# Altium schematic sheet J19B_EM3B_PP0_MCU.SchDoc
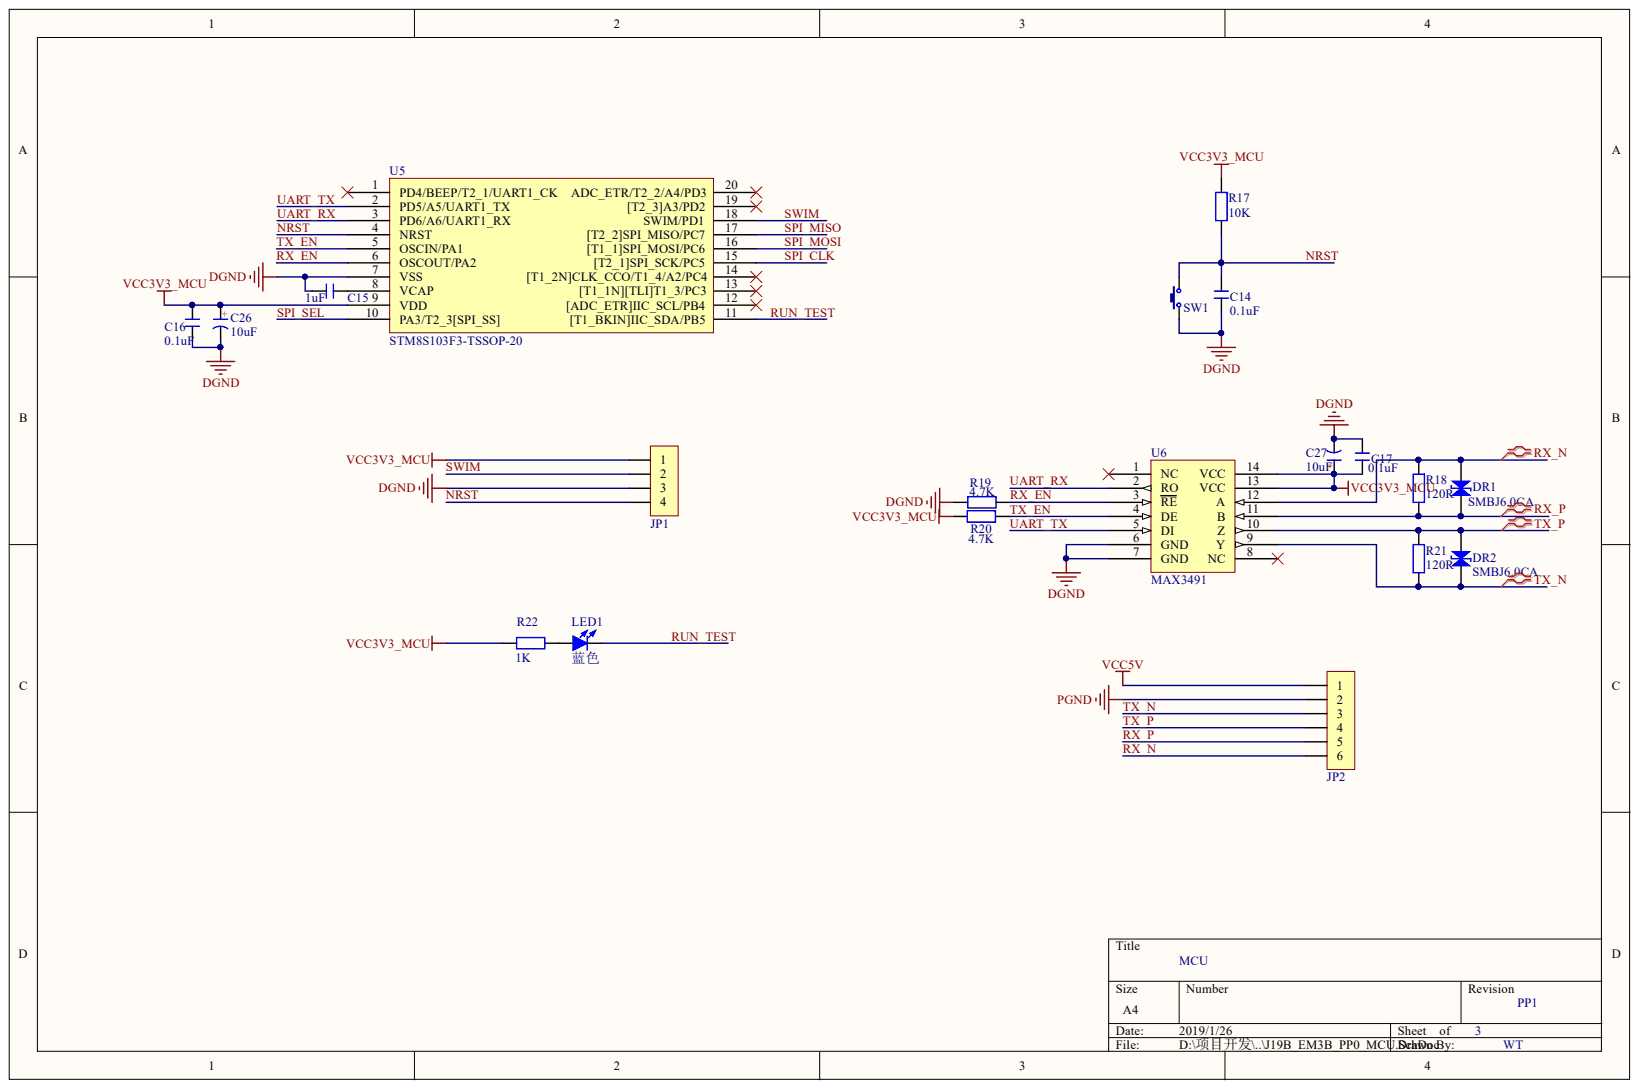
[diagram p0: J19B_EM3B_PP0_MCU.SchDoc]

## Parts
C14  0.1uF  [C 0603_L]
C15  1uF  [C 0603_L]
C16  0.1uF  [C 0603_L]
C17  0.1uF  [C 0603_L]
C26  10uF  [CD A(3216)]
C27  10uF  [CD A(3216)]
DR1  SMBJ6.0CA  [SMB]
DR2  SMBJ6.0CA  [SMB]
JP1  HDR-1X4  [HDR2.54-LI-4P]  (value not drawn)
JP2  HDR-1X6  [XH2.54-WI-6P]  (value not drawn)
LED1  蓝色  [LED 0603B]
R17  10K  [R 0603_L]
R18  120R  [R 0603_L]
R19  4.7K  [R 0603_L]
R20  4.7K  [R 0603_L]
R21  120R  [R 0603_L]
R22  1K  [R 0603_L]
SW1  3x6x5  [TSW SMD-3*6*2.5]  (value not drawn)
U5  STM8S103F3-TSSOP-20  [TSSOP20_N]
U6  MAX3491  [SOP14_N]

## Nets
DGND: C14.1 C15.1 C16.1 C17.2 C26.2 C27.2 JP1.3 R19.2 SW1.1 U5.7(VSS) U6.6(GND) U6.7(GND)
PGND: JP2.2
VCC3V3_MCU: C16.2 C17.1 C26.1 C27.1 JP1.1 R17.2 R20.1 R22.2 U5.9(VDD) U6.13(VCC) U6.14(VCC)
VCC5V: JP2.1
NRST: C14.2 JP1.4 R17.1 SW1.2 U5.4(NRST)
RUN_TEST: LED1.2 U5.11([T1_BKIN]IIC_SDA/PB5)
RX_EN: R19.1 U5.6(OSCOUT/PA2) U6.3(RE)
RX_N: DR1.1 JP2.6 R18.2 U6.12(A)
RX_P: DR1.2 JP2.5 R18.1 U6.11(B)
SPI_CLK: U5.15([T2_1]SPI_SCK/PC5)
SPI_MISO: U5.17([T2_2]SPI_MISO/PC7)
SPI_MOSI: U5.16([T1_1]SPI_MOSI/PC6)
SPI_SEL: U5.10(PA3/T2_3[SPI_SS])
SWIM: JP1.2 U5.18(SWIM/PD1)
TX_EN: R20.2 U5.5(OSCIN/PA1) U6.4(DE)
TX_N: DR2.2 JP2.3 R21.1 U6.9(Y)
TX_P: DR2.1 JP2.4 R21.2 U6.10(Z)
UART_RX: U5.3(PD6/A6/UART1_RX) U6.2(RO)
UART_TX: U5.2(PD5/A5/UART1_TX) U6.5(DI)
NetC15_2: C15.2 U5.8(VCAP)
NetLED1_1: LED1.1 R22.1

Not connected: U5 1(PD4/BEEP/T2_1/UART1_CK) 12([ADC_ETR]IIC_SCL/PB4) 13([T1_1N][TLI]T1_3/PC3) 14([T1_2N]CLK_CCO/T1_4/A2/PC4) 19([T2_3]A3/PD2) 20(ADC_ETR/T2_2/A4/PD3); U6 1(NC) 8(NC)

## Library data of the parts
C14-C17 = C: 无极性贴片电容; 版权归属: 源创客; BOM名称: 无极性贴片电容; Component Kind: Standard; Library Name: 3.1   - 电阻-电容-电感.SchLib; 学习资源: www.ooocke.com
C26 C27 = C-CD: 贴片钽电容; 版权归属: 源创客; BOM名称: 贴片钽电容; Component Kind: Standard; Library Name: 3.1   - 电阻-电容-电感.SchLib; 学习资源: www.ooocke.com
DR1 DR2 = DR: 瞬态电压抑制二极管; 版权归属: 源创客; BOM名称: 瞬态电压抑制二极管; Component Kind: Standard; Library Name: 8.1   - 二极管-整流桥.SchLib; 学习资源: www.ooocke.com
JP1 = Header 4: 4P接插件; 版权归属: 源创客; BOM名称: 4P接插件; Component Kind: Standard; Library Name: 4.5   - 接插件-脚距2.54.SchLib; 学习资源: www.ooocke.com
JP2 = Header 6: 6P接插件; 版权归属: 源创客; BOM名称: 6P接插件; Component Kind: Standard; Library Name: 4.5   - 接插件-脚距2.54.SchLib; 学习资源: www.ooocke.com
LED1 = LED-SMD: 贴片LED; 版权归属: 源创客; BOM名称: 贴片LED; Component Kind: Standard; Library Name: 9.1   - LED.SchLib; 学习资源: www.ooocke.com
R17-R22 = R: 贴片电阻; 版权归属: 源创客; BOM名称: 贴片电阻; Component Kind: Standard; Library Name: 3.1   - 电阻-电容-电感.SchLib; 学习资源: www.ooocke.com
SW1 = TSW 3x6: 3x6轻触开关; 版权归属: 源创客; BOM名称: 3x6轻触开关; Component Kind: Standard; Library Name: 5.1   - 按键-开关.SchLib; 学习资源: www.ooocke.com
U5 = STM8S103F3: Flash: 8K,     RAM: 1K,  IO: 16; BOM名称: 8位STM单片机; 版权归属: 源创客; Component Kind: Standard; Library Name: 2.2   - STM8系列单片机.SchLib; 学习资源: www.ooocke.com
U6 = MAX3491: Component Kind: Standard; Library Name: Z18B_EM3B_PP1.SchLib
